# Altium schematic sheet Sheet2.SchDoc
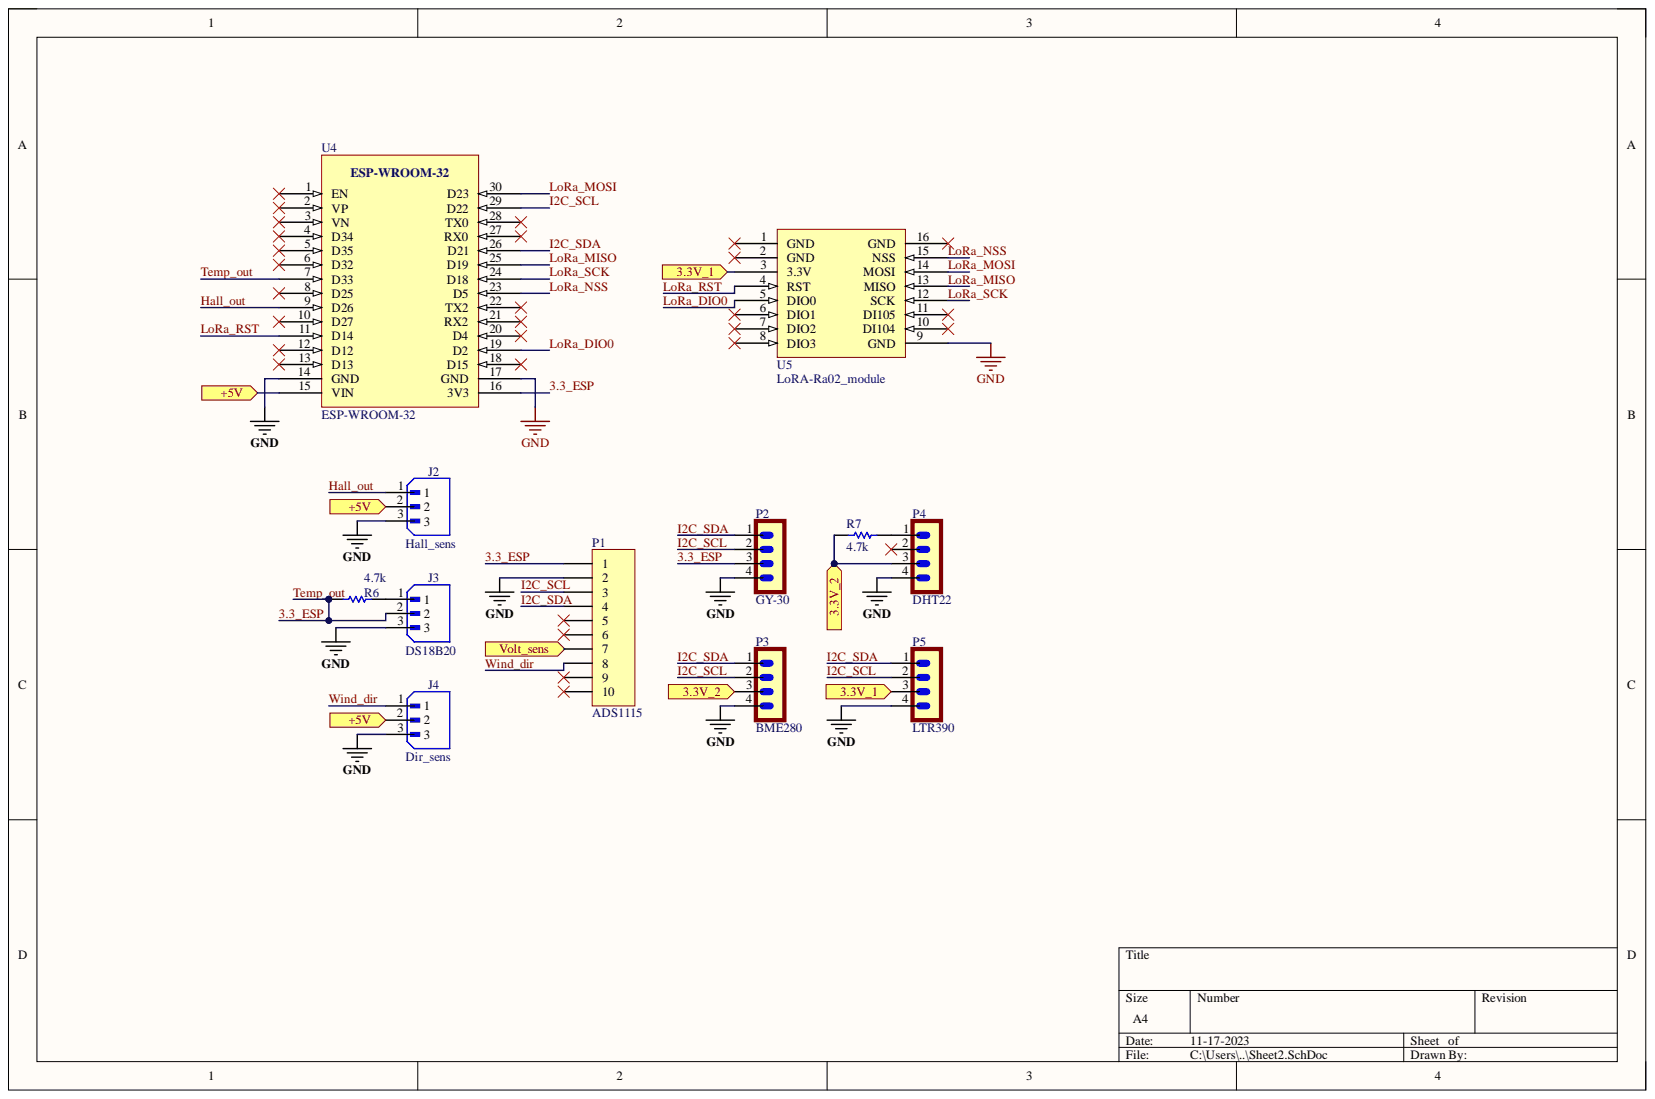
[diagram p0: Sheet2.SchDoc]

## Parts
J2  Hall_sens  [JST_B3B-XH-A (LF)(SN)]
J3  DS18B20  [JST_B3B-XH-A (LF)(SN)]
J4  Dir_sens  [JST_B3B-XH-A (LF)(SN)]
P1  ADS1115  [HDR1X10]
P2  GY-30  [B4B-PH-K]
P3  BME280  [B4B-PH-K]
P4  DHT22  [B4B-PH-K]
P5  LTR390  [B4B-PH-K]
R6  value 4.7k  [AXIAL-0.3]  (hidden comment: Res1)
R7  value 4.7k  [AXIAL-0.3]  (hidden comment: Res1)
U4  ESP-WROOM-32  [ESP-WROOM-32]
U5  LoRA-Ra02_module  [LoRA-Ra02_module]

## Ports
3.3V_1, 3.3V_2, +5V, Volt_sens

## Nets
GND: J2.3 J3.3 J4.3 P1.2 P2.4 P3.4 P4.4 P5.4 U4.14(GND) U4.17(GND) U5.9(GND)
3.3_ESP: J3.2 P1.1 P2.3 R6.2 U4.7(D33) U4.16(3V3)
3.3V_1: P5.3 U5.3(3.3V) ; port 3.3V_1
3.3V_2: P3.3 P4.3 R7.2 ; port 3.3V_2
+5V: J2.2 J4.2 U4.15(VIN) ; port +5V
Hall_out: J2.1 U4.9(D26)
I2C_SCL: P1.3 P2.2 P3.2 P5.2 U4.29(D22)
I2C_SDA: P1.4 P2.1 P3.1 P5.1 U4.26(D21)
LoRa_DIO0: U4.19(D2) U5.5(DIO0)
LoRa_MISO: U4.25(D19) U5.13(MISO)
LoRa_MOSI: U4.30(D23) U5.14(MOSI)
LoRa_NSS: U4.23(D5) U5.15(NSS)
LoRa_RST: U4.11(D14) U5.4(RST)
LoRa_SCK: U4.24(D18) U5.12(SCK)
Volt_sens: P1.7 ; port Volt_sens
Wind_dir: J4.1 P1.8
NetJ3_1: J3.1 R6.1
NetP4_1: P4.1 R7.1

Not connected: P1 5 6 9 10; P4 2; U4 1(EN) 2(VP) 3(VN) 4(D34) 5(D35) 6(D32) 8(D25) 10(D27) 12(D12) 13(D13) 18(D15) 20(D4) 21(RX2) 22(TX2) 27(RX0) 28(TX0); U5 1(GND) 2(GND) 6(DIO1) 7(DIO2) 8(DIO3) 10(DI104) 11(DI105) 16(GND)

## Library data of the parts
J2-J4 = B3B-XH-A_(LF)(SN): Connector Header Through Hole 3 position 0.098 (2.50mm); MF: JST Sales America Inc.; MP: B3B-XH-A (LF)(SN); Check_prices: https://www.snapeda.com/parts/B3B-XH-A%20(LF)(SN)/JST+Sales+America+Inc./view-part/?ref=eda; Component Kind: Standard; Library Name: B3B-XH-A__LF__SN_.IntLib; Package: None; SnapEDA_Link: https://www.snapeda.com/parts/B3B-XH-A%20(LF)(SN)/JST+Sales+America+Inc./view-part/?ref=snap
P1 = Header 10: Header, 10-Pin; Component Kind: Standard; LatestRevisionDate: 17-Jul-2002; LatestRevisionNote: Re-released for DXP Platform.; Library Name: Miscellaneous Connectors.IntLib; Signal Integrity: Connector; Publisher: Altium Limited
P2-P5 = B4B-PH-K: Connector Header Through Hole 4 position 0.079 (2.00mm); MF: JST; Availability: In Stock; Check_prices: https://www.snapeda.com/parts/B4B-PH-K/JST/view-part/?ref=eda; Component Kind: Standard; Library Name: B4B-PH-K.IntLib; MP: B4B-PH-K; Package: None; Price: None; Purchase-URL: https://pricing.snapeda.com/search/part/B4B-PH-K/?ref=eda; SnapEDA_Link: https://www.snapeda.com/parts/B4B-PH-K/JST/view-part/?ref=snap
R6 R7 = Res1: Resistor; Component Kind: Standard; LatestRevisionDate: 17-Jul-2002; LatestRevisionNote: Re-released for DXP Platform.; Library Name: Miscellaneous Devices.IntLib; PackageDescription: Axial Device, Thru-Hole; 2 Leads; 0.3 in Pin Spacing; PackageReference: AXIAL-0.3; Published: 8-Jun-2000; Signal Integrity: Res; Simulation: RESISTOR; Publisher: Altium Limited
U4 = ESP-WROOM-32: Component Kind: Standard; Library Name: Weather_Stn.SCHLIB
U5 = LoRA-Ra02_module: Component Kind: Standard; Library Name: Weather_Stn.SCHLIB
